# Altium schematic sheet MCU_OTHER.SchDoc
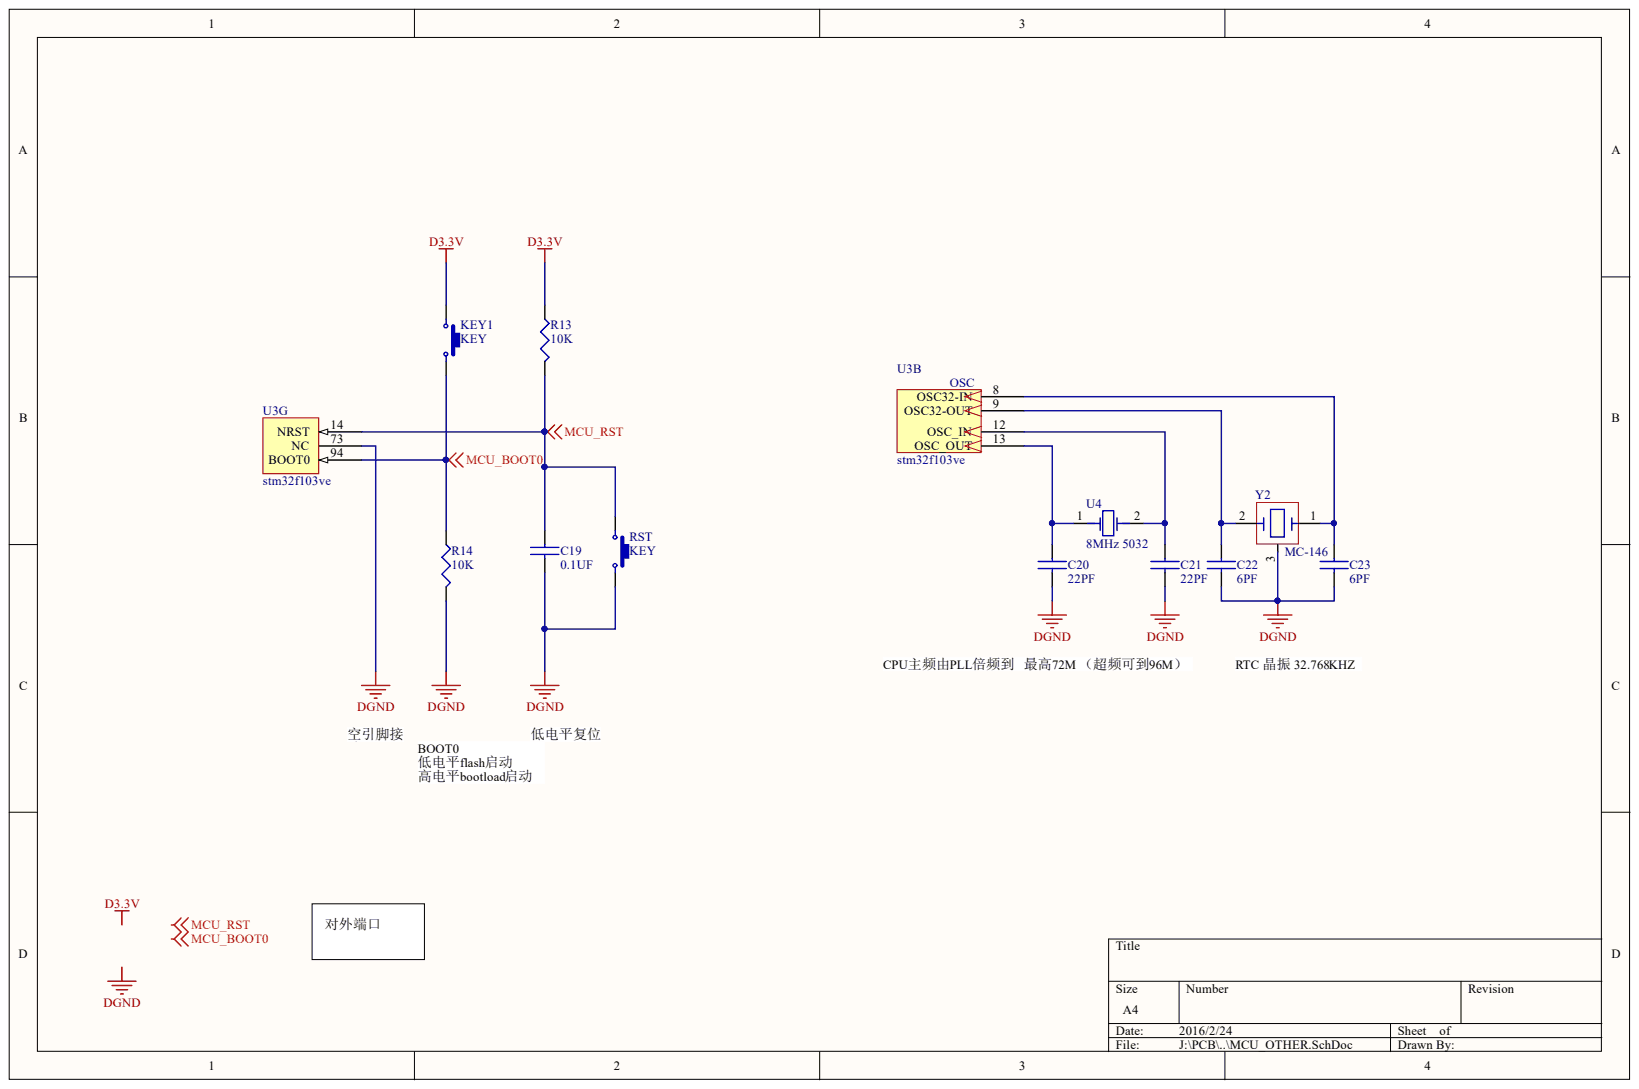
[diagram p0: MCU_OTHER.SchDoc]

## Parts
C19  0.1UF  [CAPC1005X05N]
C20  22PF  [CAPC1005X05N]
C21  22PF  [CAPC1005X05N]
C22  6PF  [CAPC1005X05N]
C23  6PF  [CAPC1005X05N]
KEY1  KEY  [KEY_DBLPin_3X6-SMT]
R13  10K  [RESC1005X04N]
R14  10K  [RESC1005X04N]
RST  KEY  [KEY_DBLPin_3X6-SMT]
U3  stm32f103ve  [LQFP100-M]  (parts B,G)
U4  8MHz 5032  [5032_DFN370P500X135-2N]
Y2  MC-146  [MC-146]

## Nets
D3.3V: KEY1.1 R13.1
DGND: C19.2 C20.2 C21.2 C22.2 C23.2 R14.2 RST.2 U3.73(NC) Y2.3
MCU_BOOT0: KEY1.2 R14.1 U3.94(BOOT0)
MCU_RST: C19.1 R13.2 RST.1 U3.14(NRST)
NetC20_1: C20.1 U3.13(OSC_OUT) U4.1
NetC21_1: C21.1 U3.12(OSC_IN) U4.2
NetC22_1: C22.1 U3.9(OSC32-OUT) Y2.2
NetC23_1: C23.1 U3.8(OSC32-IN) Y2.1

## Library data of the parts
C19-C23 = CAP: Capacitor nonpolarity; Component Kind: Standard; Library Name: RCL_Standard.SchLib
KEY1 RST = KEY: 两脚微动开关; Component Kind: Standard; Library Name: Other.SchLib
R13 R14 = R: General Resistors; Component Kind: Standard; Library Name: RCL_Standard.SchLib
U3 = STM32F103V: MCU; Component Kind: Standard; Library Name: IC.SchLib
U4 = Crystal: 晶体; Component Kind: Standard; Library Name: Other.SchLib
Y2 = MC-146: 工业级晶体，32.768k; Component Kind: Standard; Library Name: Other.SchLib
